# Altium schematic sheet Overview.SchDoc(Overview)
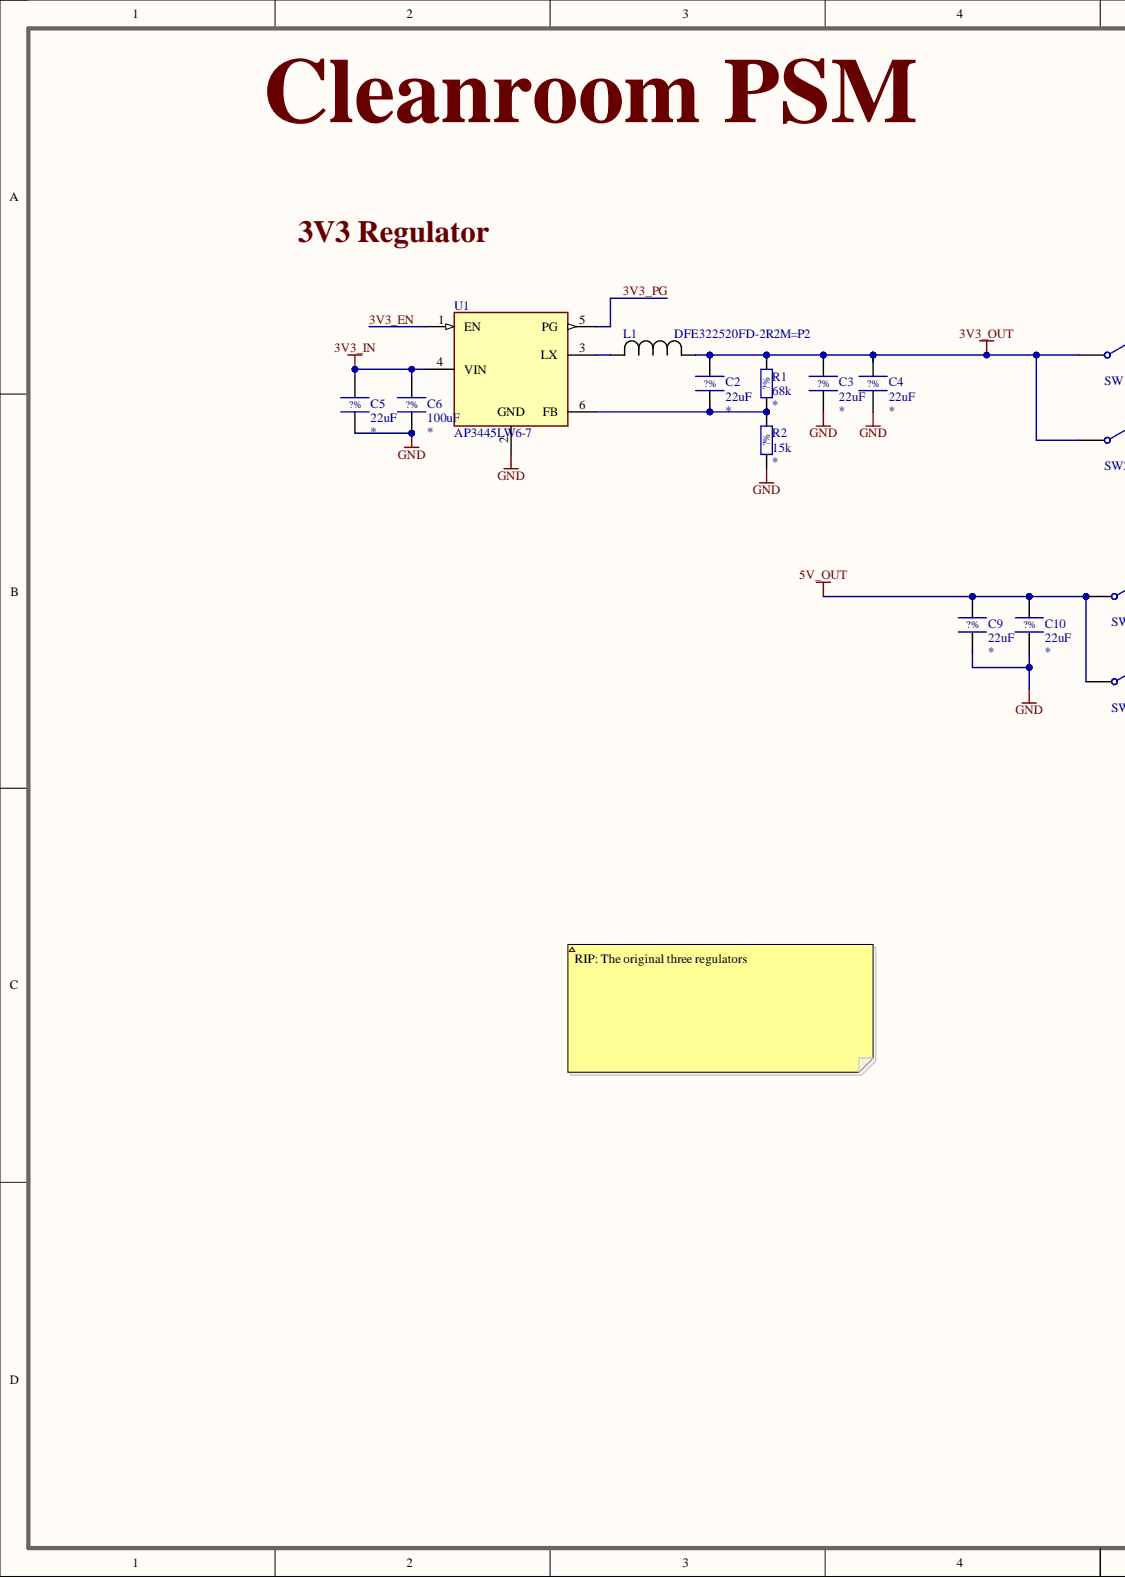
[diagram p0: Overview.SchDoc, left]
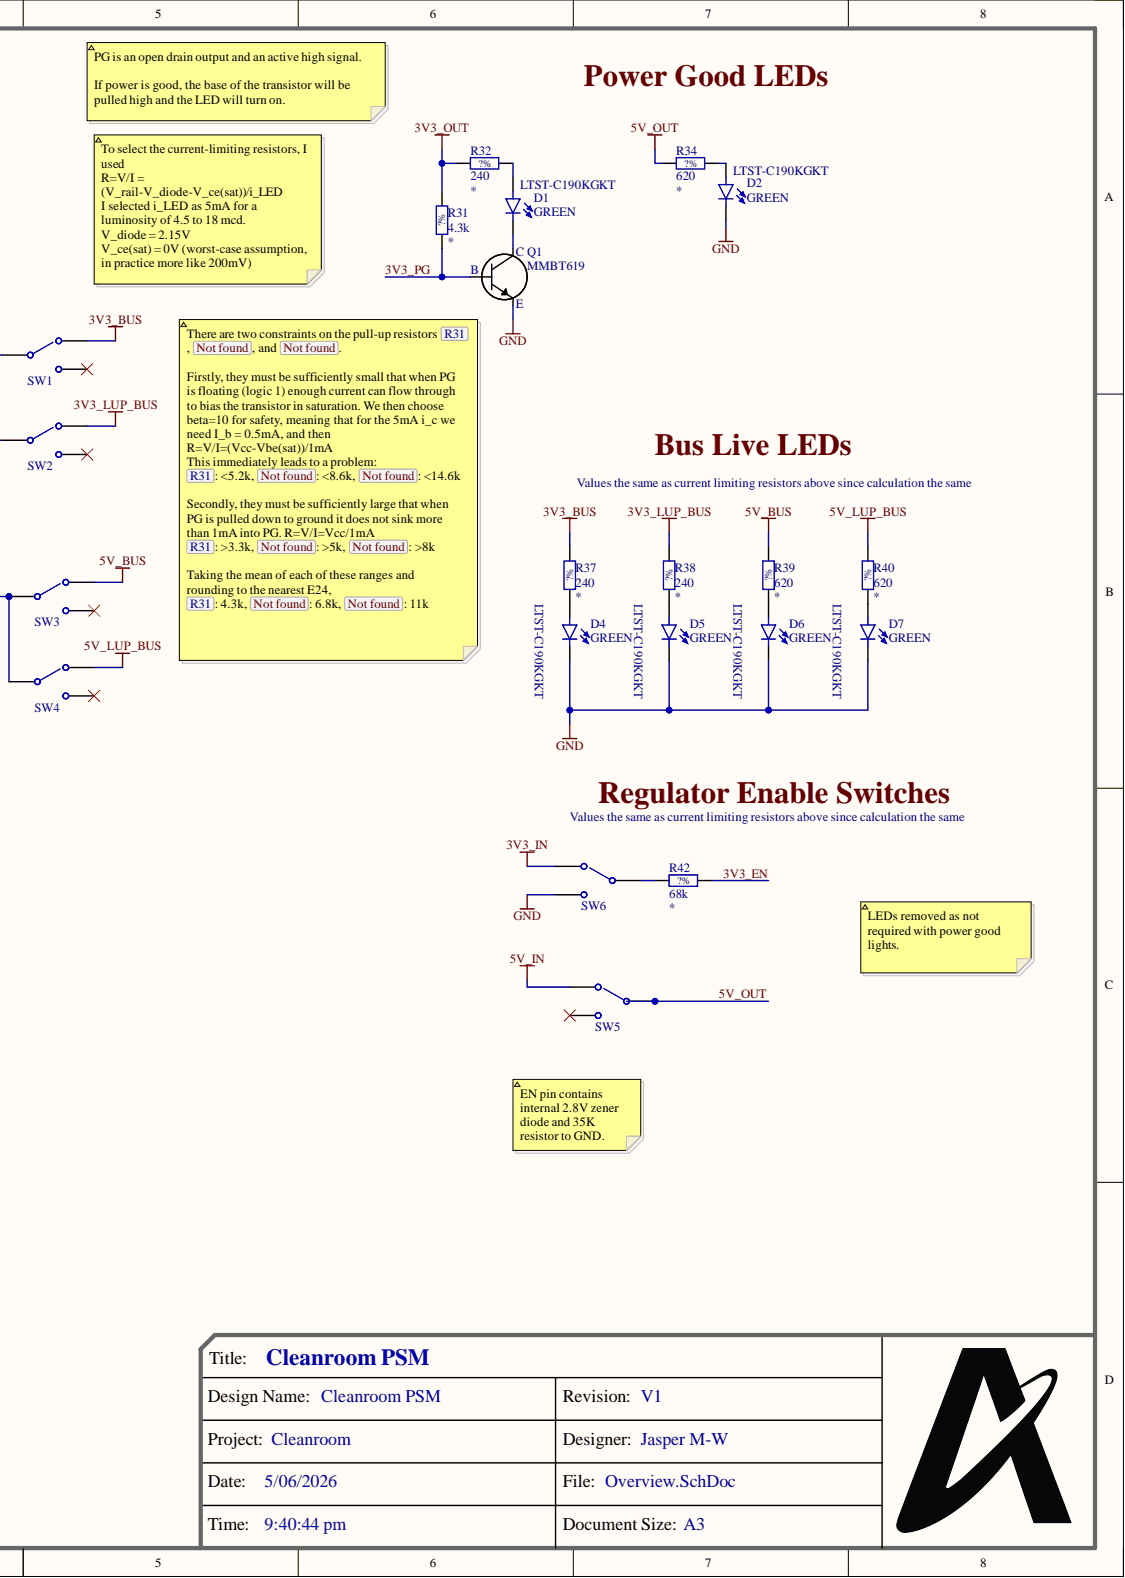
[diagram p1: Overview.SchDoc, right]

## Parts
C2  22uF  Tolerance: ?%  [CAPACITOR_0805_2012M-70N]
C3  22uF  Tolerance: ?%  [CAPACITOR_0805_2012M-70N]
C4  22uF  Tolerance: ?%  [CAPACITOR_0805_2012M-70N]
C5  22uF  Tolerance: ?%  [CAPACITOR_0805_2012M-70N]
C6  100uF  Tolerance: ?%  [CAPACITOR_0805_2012M-70N]
C9  22uF  Tolerance: ?%  [CAPACITOR_0805_2012M-70N]
C10  22uF  Tolerance: ?%  [CAPACITOR_0805_2012M-70N]
D1  Colour: GREEN  MF Part No.: LTST-C190KGKT  [LED_0603_1608M_0.80mm]  (hidden comment: LTST-C190 Series)
D2  Colour: GREEN  MF Part No.: LTST-C190KGKT  [LED_0603_1608M_0.80mm]  (hidden comment: LTST-C190 Series)
D4  Colour: GREEN  MF Part No.: LTST-C190KGKT  [LED_0603_1608M_0.80mm]  (hidden comment: LTST-C190 Series)
D5  Colour: GREEN  MF Part No.: LTST-C190KGKT  [LED_0603_1608M_0.80mm]  (hidden comment: LTST-C190 Series)
D6  Colour: GREEN  MF Part No.: LTST-C190KGKT  [LED_0603_1608M_0.80mm]  (hidden comment: LTST-C190 Series)
D7  Colour: GREEN  MF Part No.: LTST-C190KGKT  [LED_0603_1608M_0.80mm]  (hidden comment: LTST-C190 Series)
L1  DFE322520FD-2R2M=P2  [IND_DFE3225_MUR]
Q1  MMBT619  [SOT23-3N-1.15mm]
R1  68k  Tolerance: ?%  [RESISTOR_0805_2012M-50N]
R2  15k  Tolerance: ?%  [RESISTOR_0805_2012M-50N]
R31  4.3k  Tolerance: ?%  [RESISTOR_0805_2012M-50N]
R32  240  Tolerance: ?%  [RESISTOR_0805_2012M-50N]
R34  620  Tolerance: ?%  [RESISTOR_0805_2012M-50N]
R37  240  Tolerance: ?%  [RESISTOR_0805_2012M-50N]
R38  240  Tolerance: ?%  [RESISTOR_0805_2012M-50N]
R39  620  Tolerance: ?%  [RESISTOR_0805_2012M-50N]
R40  620  Tolerance: ?%  [RESISTOR_0805_2012M-50N]
R42  68k  Tolerance: ?%  [RESISTOR_0805_2012M-50N]
SW1  ANT11SF1CQE  [ANT11SF1CQE]  (value not drawn)
SW2  ANT11SF1CQE  [ANT11SF1CQE]  (value not drawn)
SW3  ANT11SF1CQE  [ANT11SF1CQE]  (value not drawn)
SW4  ANT11SF1CQE  [ANT11SF1CQE]  (value not drawn)
SW5  ANT11SF1CQE  [ANT11SF1CQE]  (value not drawn)
SW6  ANT11SF1CQE  [ANT11SF1CQE]  (value not drawn)
U1  AP3445LW6-7  [SOT23-6N-1.4mm]

## Nets
3V3_BUS: R37.2 SW1.1
3V3_IN: C5.2 C6.2 SW6.1 U1.4(VIN)
3V3_LUP_BUS: R38.2 SW2.1
3V3_OUT: C2.2 C3.2 C4.2 L1.1 R1.2 R31.2 R32.1 SW1.2 SW2.2
5V_BUS: R39.2 SW3.1
5V_IN: SW5.1
5V_LUP_BUS: R40.2 SW4.1
GND: C3.1 C4.1 C5.1 C6.1 C9.1 C10.1 D2.C D4.C D5.C D6.C D7.C Q1.2 R2.1 SW6.3 U1.2
3V3_EN: R42.2 U1.1(EN)
3V3_PG: Q1.1 R31.1 U1.5(PG)
5V_OUT: C9.2 C10.2 R34.1 SW3.2 SW4.2 SW5.2
NetC2_1: C2.1 R1.1 R2.2 U1.6(FB)
NetD1_A: D1.A R32.2
NetD1_C: D1.C Q1.3
NetD2_A: D2.A R34.2
NetD4_A: D4.A R37.1
NetD5_A: D5.A R38.1
NetD6_A: D6.A R39.1
NetD7_A: D7.A R40.1
NetL1_2: L1.2 U1.3(LX)
NetR42_1: R42.1 SW6.2

Not connected: SW1 3; SW2 3; SW3 3; SW4 3; SW5 3

## Library data of the parts
C2-C6 C9 C10 = Generic Capacitor: Passive - Capacitor, Generic; Component Kind: Standard; Library Name: APSS Generic Components (3).SchLib
  C2-C5 C9 C10: Value: 22uF
  C6: Value: 100uF
D1 D2 D4-D7 = LTST-C190 Series: Diode - LED, 0603; APSS Standard Part: APSS Standard; Rated Voltage: 5V Reverse; Temp Range: -30°C ~ 85°C; Component Kind: Standard; Library Name: APSS Prototype Parts.SchLib; Package: 0603; Supplier 1: Digikey
L1 = DFE322520FD-2R2M=P2: Description; Manufacturer_Name: Murata; Type: Fixed Inductors; Component Kind: Standard; Library Name: DFE322520FD-2R2MP2.SchLib; Manufacturer_Part_Number: DFE322520FD-2R2M=P2; Copyright: Copyright (C) 2025 Ultra Librarian. All rights reserved.
Q1 = MMBT619: Transistor - BJT NPN MMBT619; APSS Standard Part: APSS Standard; Power: 350mW; Rated Voltage: 50 Vce; Temp Range: -55°C ~ 150°C (TJ); Component Kind: Standard; Library Name: APSS Prototype Parts.SchLib; MF Part No.: MMBT619; Package: SOT-23-3; Supplier 1: Digikey; Supplier 1 Part No.: 4786-MMBT619CT-ND
R1 R2 R31 R32 R34 R37-R40 R42 = Generic Resistor: Passive - Resistor, Generic; Component Kind: Standard; Library Name: APSS Generic Components (3).SchLib
  R1 R42: Value: 68k
  R2: Value: 15k
  R31: Value: 4.3k
  R32 R37 R38: Value: 240
  R34 R39 R40: Value: 620
SW1-SW6 = ANT11SF1CQE: Switch - Toggle, SPDT, 5A @ 28VDC; Temp Range: -40°C ~ 85°C (TA); Circuit: SPDT; Component Kind: Standard; Library Name: ANT11SF1CQE.SchLib; MF Part No.: ANT11SF1CQE; Package: -; Rated Current: 5A; Rated Voltage: 28 VDC; Supplier 1: Digikey; Supplier 1 Part No.: 2449-ANT11SF1CQE-ND
U1 = AP3445LW6-7: IC - Regulator, Buck, 2A, 2.7V to 5.5V In, 0.6V to Vin Out, SOT23-6; APSS Standard Part: APSS Standard; Temp Range: -40°C ~ 85°C (TA); Component Kind: Standard; Library Name: APSS Prototype Parts.SchLib; MF Part No.: AP3445LW6-7; Package: SOT-23-5; Rated Voltage: 5.5V; Supplier 1: Digikey; Supplier 1 Part No.: AP3445LW6-7DICT-ND
